# Altium schematic sheet SimpleFOCDriver.SchDoc
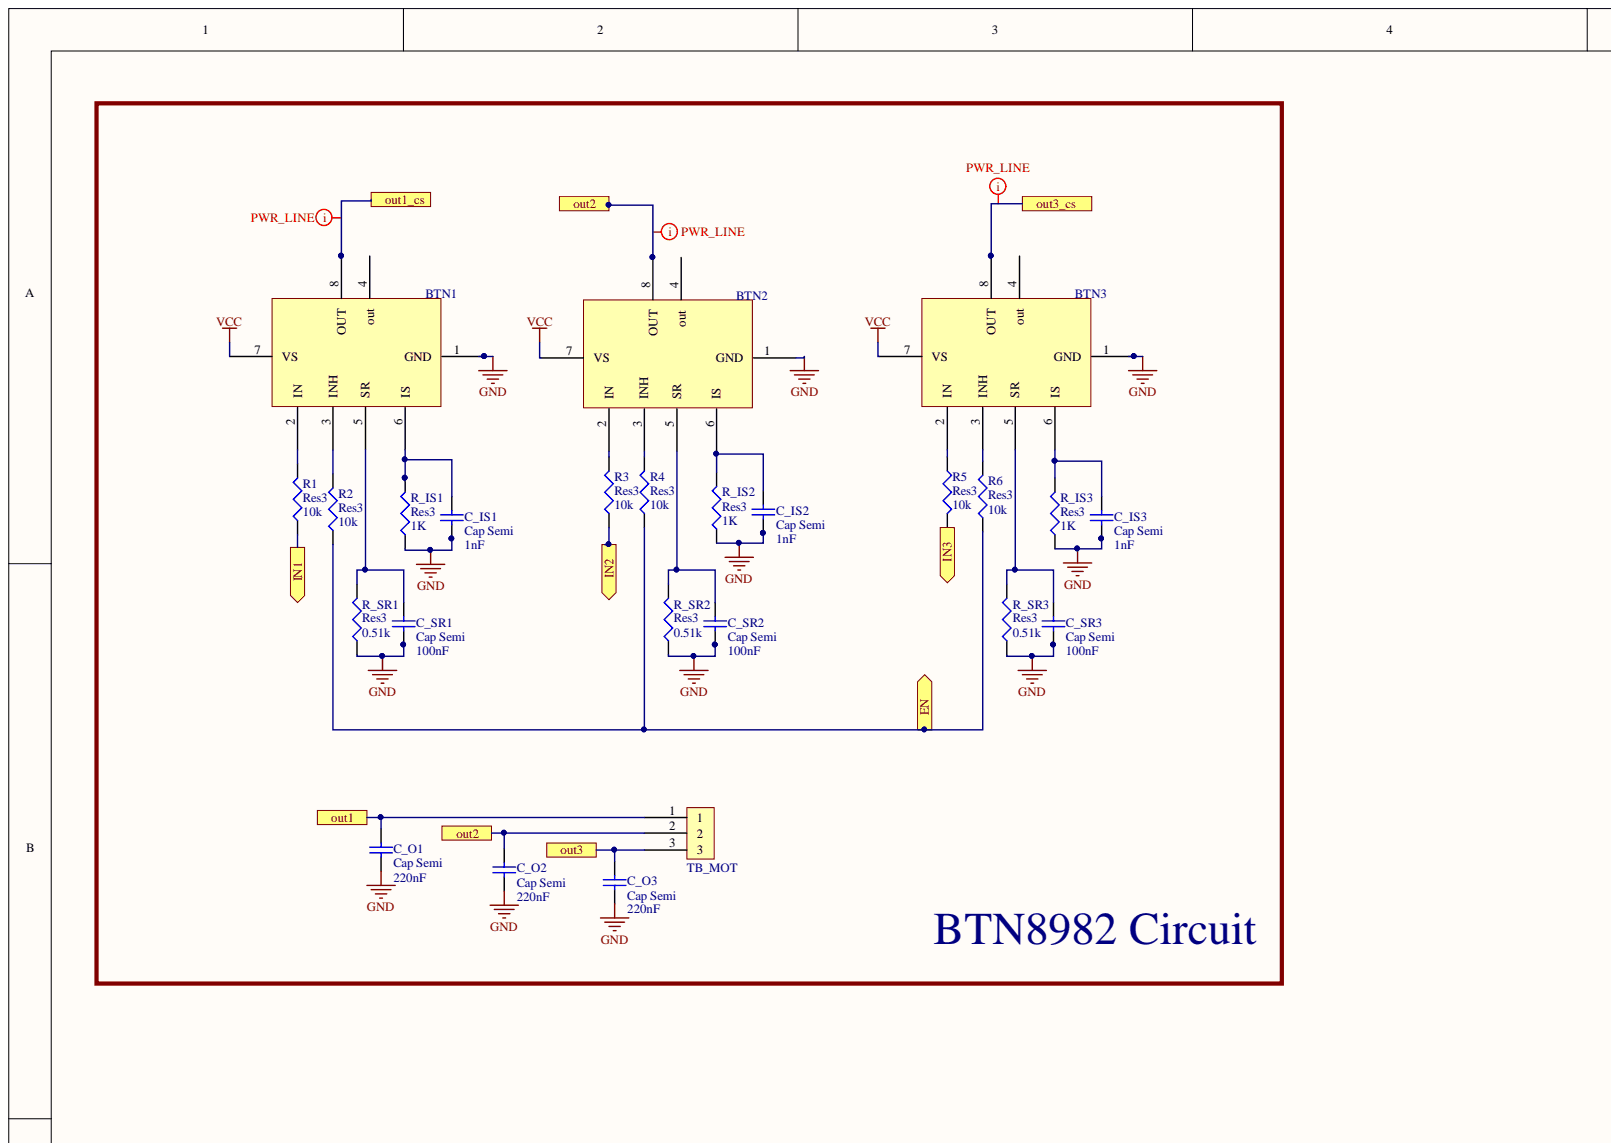
[diagram p0: SimpleFOCDriver.SchDoc, top left]
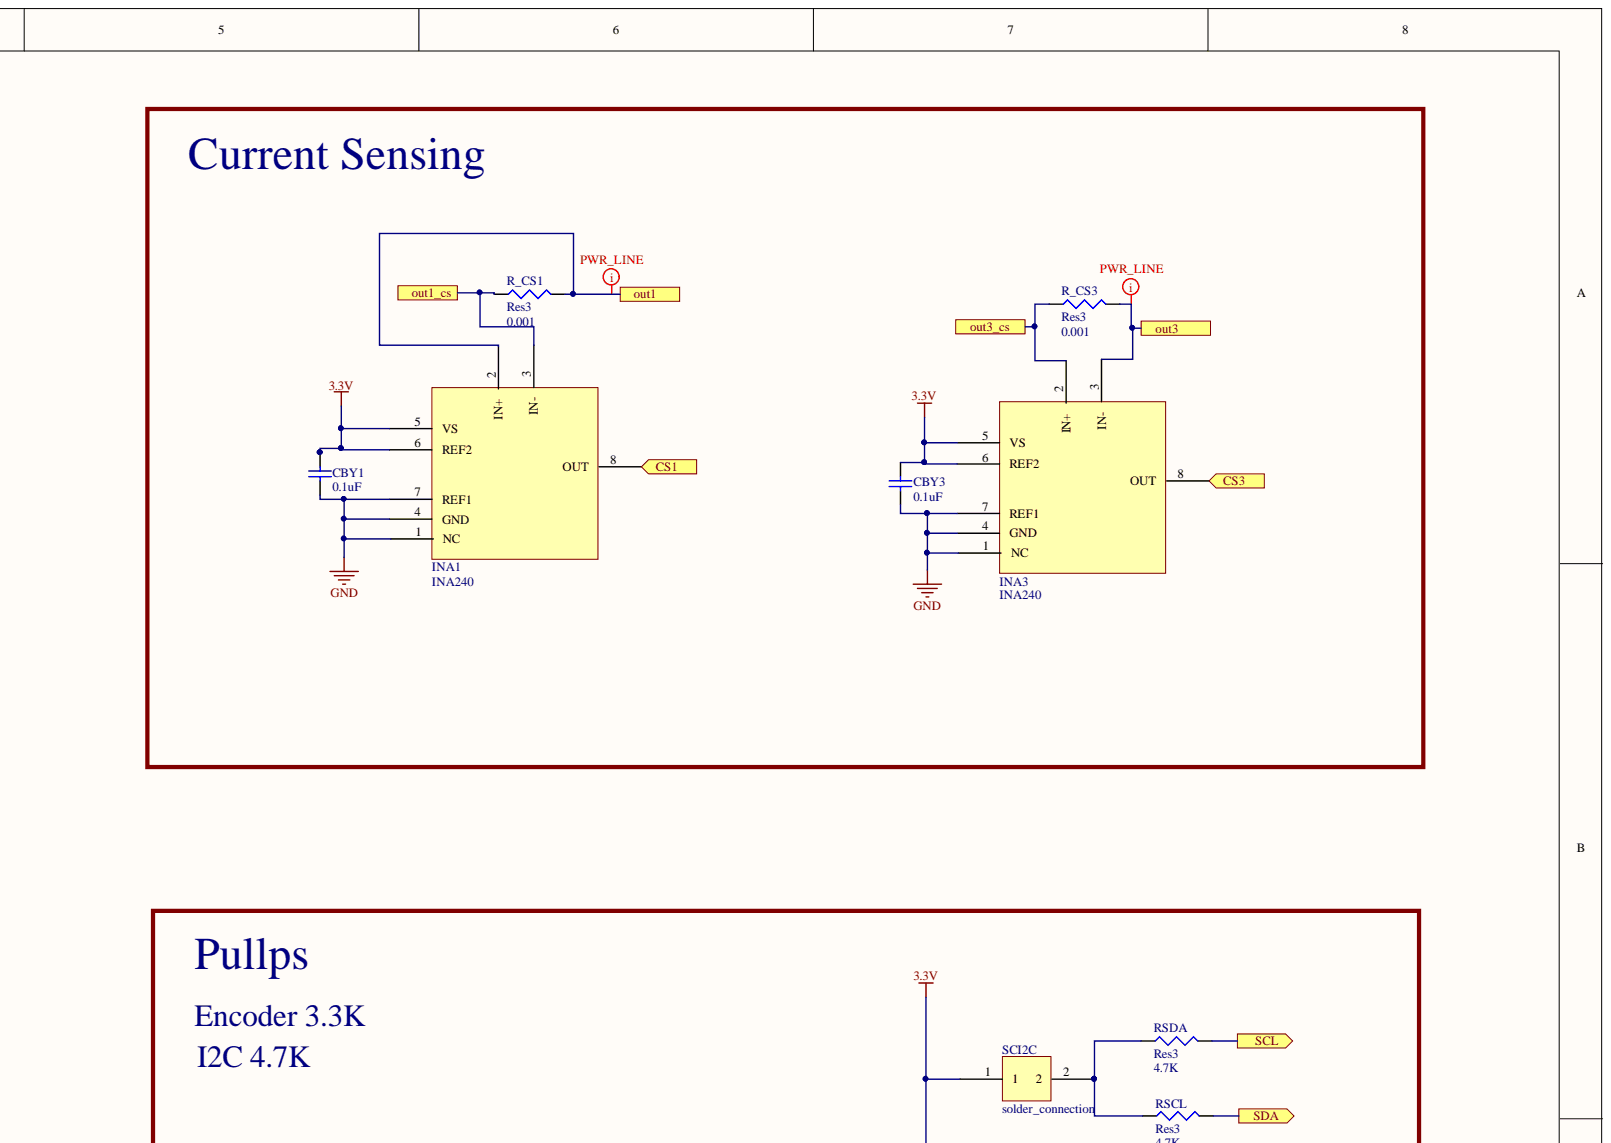
[diagram p1: SimpleFOCDriver.SchDoc, top right]
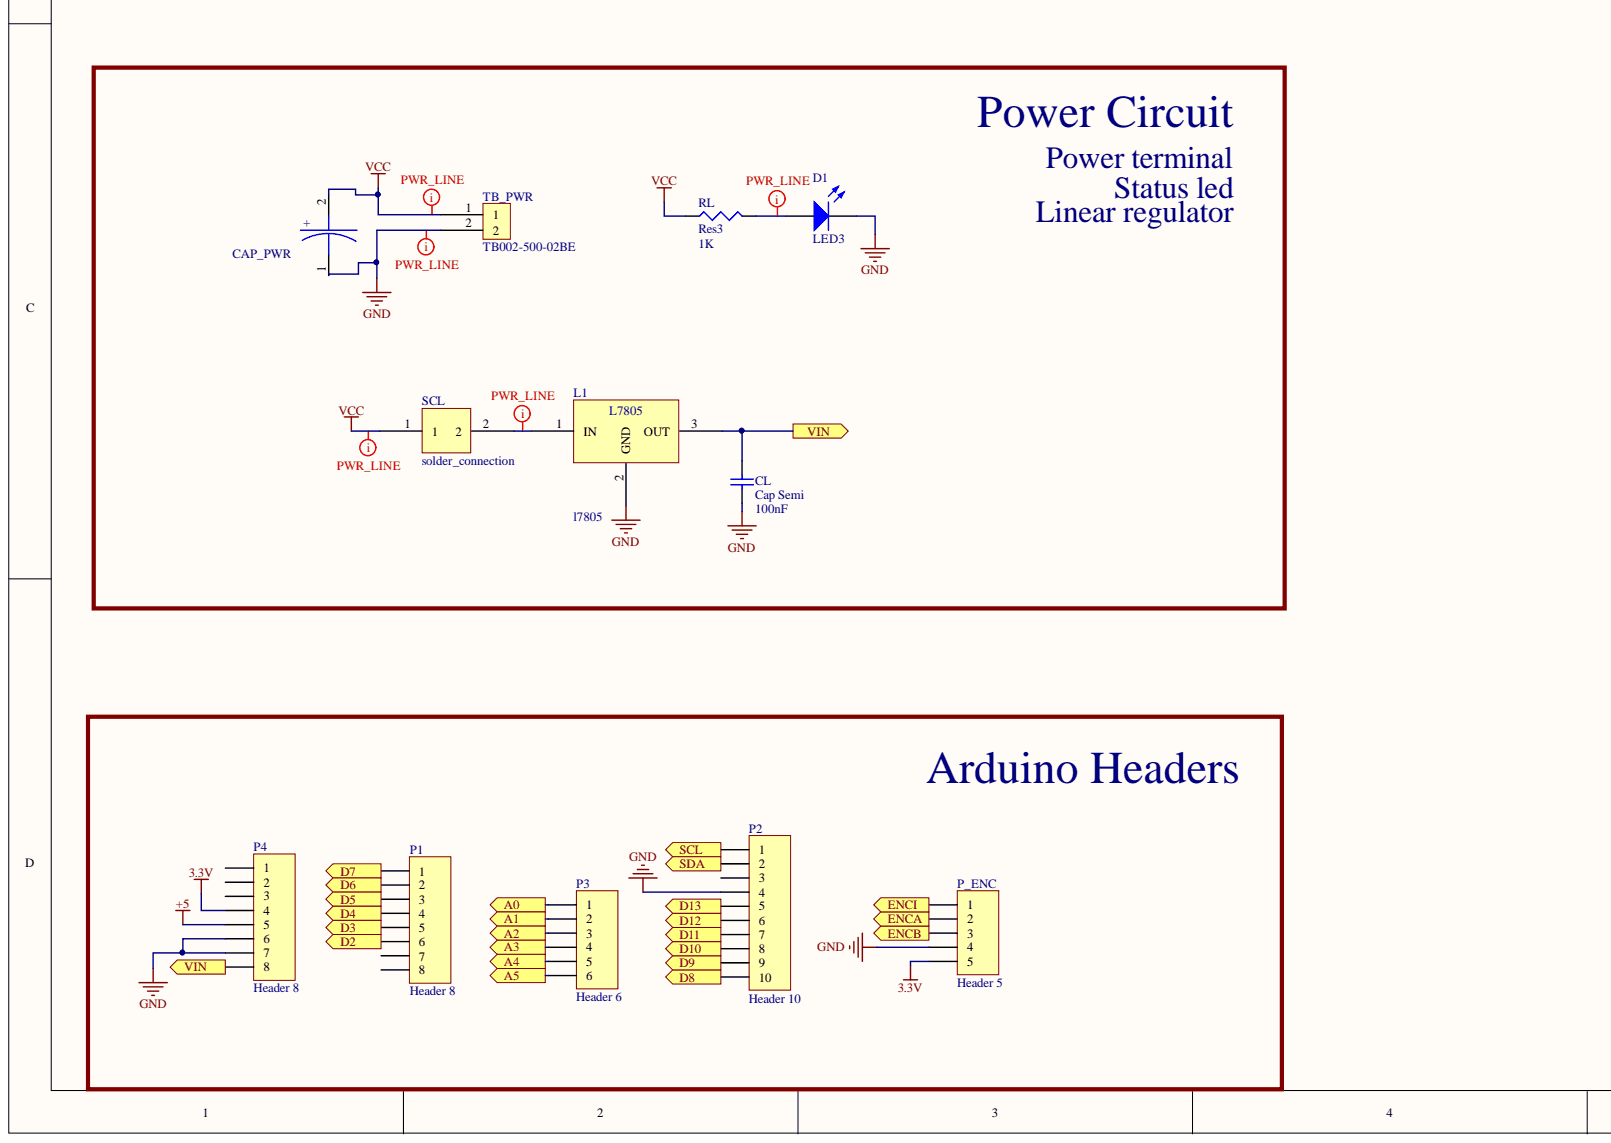
[diagram p2: SimpleFOCDriver.SchDoc, bottom left]
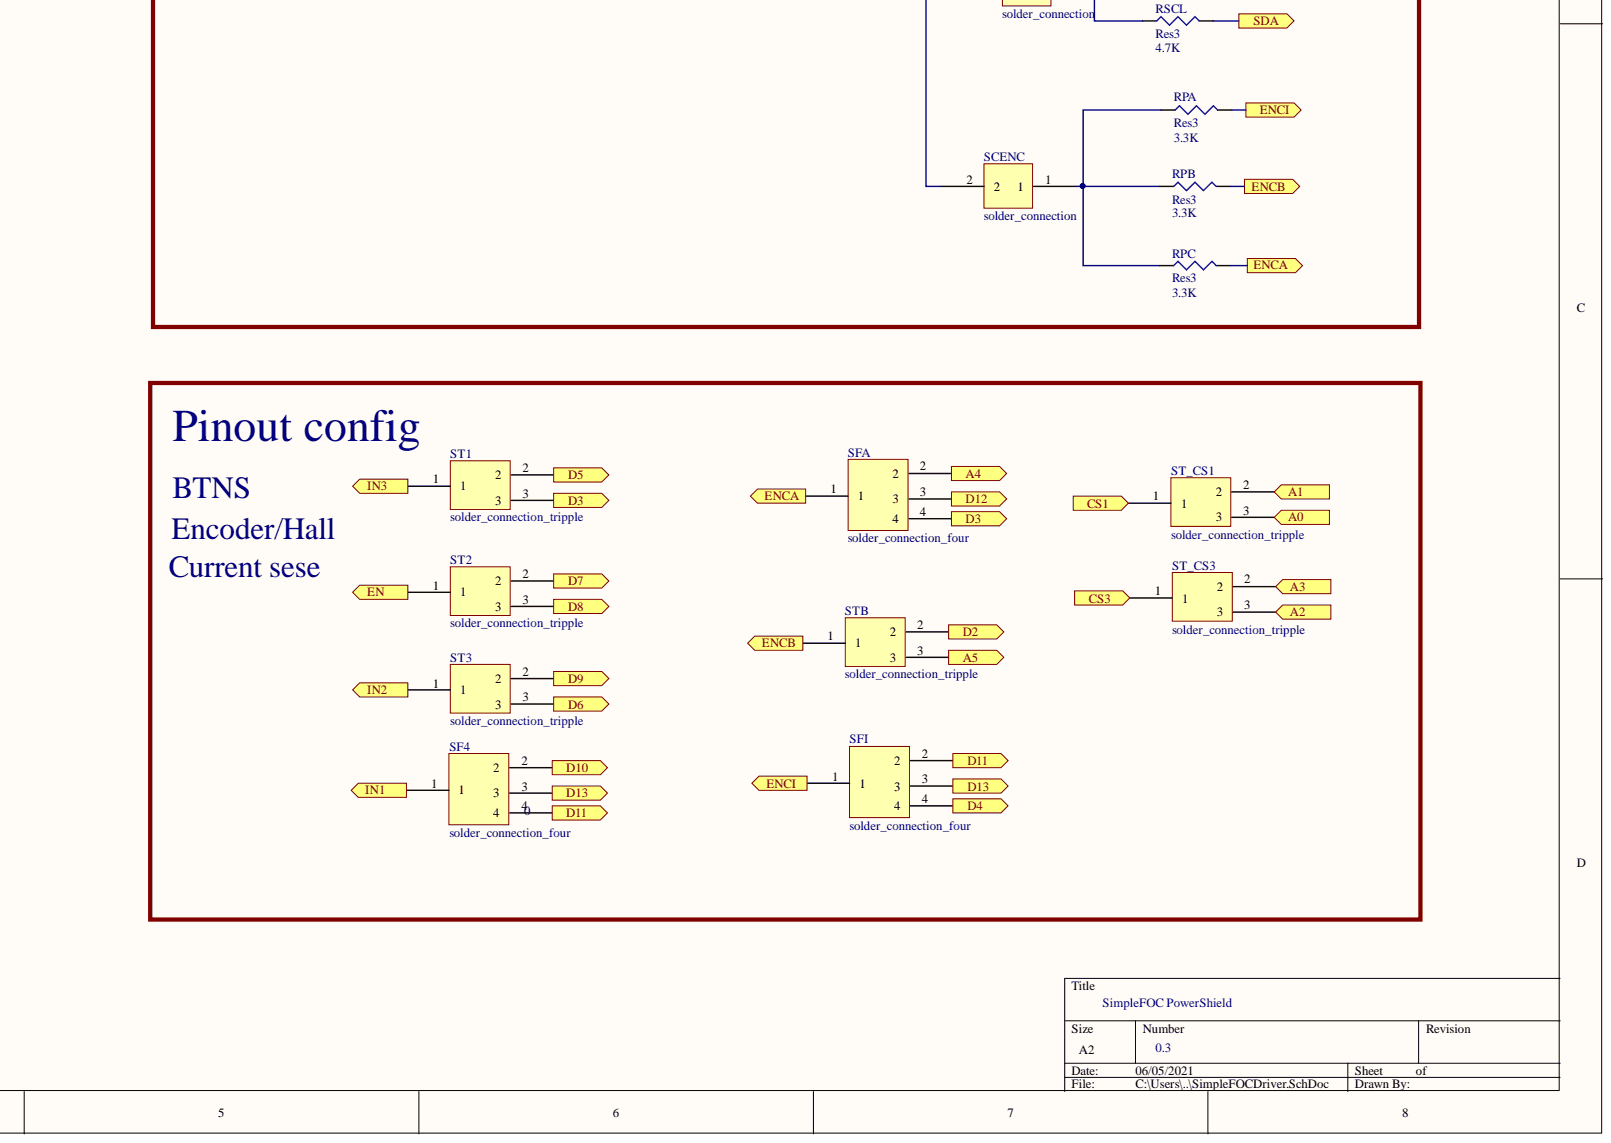
[diagram p3: SimpleFOCDriver.SchDoc, bottom right]

## Parts
BTN1  BTN8982  [BTN8982]  (value not drawn)
BTN2  BTN8982  [BTN8982]  (value not drawn)
BTN3  BTN8982  [BTN8982]  (value not drawn)
C_IS1  Cap Semi  value 1nF  [1608[0603]]
C_IS2  Cap Semi  value 1nF  [1608[0603]]
C_IS3  Cap Semi  value 1nF  [1608[0603]]
C_O1  Cap Semi  value 220nF  [1608[0603]]
C_O2  Cap Semi  value 220nF  [1608[0603]]
C_O3  Cap Semi  value 220nF  [1608[0603]]
C_SR1  Cap Semi  value 100nF  [1608[0603]]
C_SR2  Cap Semi  value 100nF  [1608[0603]]
C_SR3  Cap Semi  value 100nF  [1608[0603]]
CAP_PWR  RVT1V471M1010 VEJ471M1ETR1010  [VEJ471M1ETR1010]  (value not drawn)
CBY1  value 0.1uF  [1608[0603]]  (hidden comment: Cap Semi)
CBY3  value 0.1uF  [1608[0603]]  (hidden comment: Cap Semi)
CL  Cap Semi  value 100nF  [1608[0603]]
D1  LED3  [3.5X2.8X1.9]
INA1  INA240  [INA240]
INA3  INA240  [INA240]
L1  l7805  [L7805]
P1  Header 8  [HDR1X8]
P2  Header 10  [HDR1X10]
P3  Header 6  [HDR1X6]
P4  Header 8  [HDR1X8]
P_ENC  Header 5  [HDR1X5]
R1  Res3  value 10k  [J1-0603]
R2  Res3  value 10k  [J1-0603]
R3  Res3  value 10k  [J1-0603]
R4  Res3  value 10k  [J1-0603]
R5  Res3  value 10k  [J1-0603]
R6  Res3  value 10k  [J1-0603]
R_CS1  Res3  value 0.001  [RESC6332]
R_CS3  Res3  value 0.001  [RESC6332]
R_IS1  Res3  value 1K  [J1-0603]
R_IS2  Res3  value 1K  [J1-0603]
R_IS3  Res3  value 1K  [J1-0603]
R_SR1  Res3  value 0.51k  [J1-0603]
R_SR2  Res3  value 0.51k  [J1-0603]
R_SR3  Res3  value 0.51k  [J1-0603]
RL  Res3  value 1K  [J1-0603]
RPA  Res3  value 3.3K  [J1-0603]
RPB  Res3  value 3.3K  [J1-0603]
RPC  Res3  value 3.3K  [J1-0603]
RSCL  Res3  value 4.7K  [J1-0603]
RSDA  Res3  value 4.7K  [J1-0603]
SCENC  solder_connection  [solder_connection]
SCI2C  solder_connection  [solder_connection]
SCL  solder_connection  [solder_connection]
SF4  solder_connection_four  [solder_connection_four]
SFA  solder_connection_four  [solder_connection_four]
SFI  solder_connection_four  [solder_connection_four]
ST1  solder_connection_tripple  [solder_connection_tripple]
ST2  solder_connection_tripple  [solder_connection_tripple]
ST3  solder_connection_tripple  [solder_connection_tripple]
ST_CS1  solder_connection_tripple  [solder_connection_tripple]
ST_CS3  solder_connection_tripple  [solder_connection_tripple]
STB  solder_connection_tripple  [solder_connection_tripple]
TB_MOT  TB002-500-03BE  [TB002-500-03BE]  (value not drawn)
TB_PWR  TB002-500-02BE  [TB002-500-02BE]

## Ports
A0, A1, A2, A3, A4, A5, CS1, CS3, D2, D3, D4, D5, D6, D7, D8, D9, D10, D11, D12, D13, EN, ENCA, ENCB, ENCI, IN1, IN2, IN3, out1, out1_cs, out2, out3, out3_cs, SCL, SDA, VIN

## Nets
3.3V: CBY1.2 CBY3.2 INA1.5(VS) INA1.6(REF2) INA3.5(VS) INA3.6(REF2) P4.4 P_ENC.5 SCENC.2 SCI2C.1
+5: P4.5
GND: BTN1.1(GND) BTN2.1(GND) BTN3.1(GND) C_IS1.1 C_IS2.1 C_IS3.1 C_O1.2 C_O2.2 C_O3.2 C_SR1.1 C_SR2.1 C_SR3.1 CAP_PWR.1 CBY1.1 CBY3.1 CL.1 D1.2 INA1.1(NC) INA1.4(GND) INA1.7(REF1) INA3.1(NC) INA3.4(GND) INA3.7(REF1) L1.2 P2.4 P4.6 P4.7 P_ENC.4 R_IS1.1 R_IS2.1 R_IS3.1 R_SR1.1 R_SR2.1 R_SR3.1 TB_PWR.2
VCC: BTN1.7(VS) BTN2.7(VS) BTN3.7(VS) CAP_PWR.2 RL.1 SCL.1 TB_PWR.1
A0: P3.1 ST_CS1.3 ; port A0
A1: P3.2 ST_CS1.2 ; port A1
A2: P3.3 ST_CS3.3 ; port A2
A3: P3.4 ST_CS3.2 ; port A3
A4: P3.5 SFA.2 ; port A4
A5: P3.6 STB.3 ; port A5
CS1: INA1.8(OUT) ST_CS1.1 ; port CS1
CS3: INA3.8(OUT) ST_CS3.1 ; port CS3
D2: P1.6 STB.2 ; port D2
D3: P1.5 SFA.4 ST1.3 ; port D3
D4: P1.4 SFI.4 ; port D4
D5: P1.3 ST1.2 ; port D5
D6: P1.2 ST3.3 ; port D6
D7: P1.1 ST2.2 ; port D7
D8: P2.10 ST2.3 ; port D8
D9: P2.9 ST3.2 ; port D9
D10: P2.8 SF4.2 ; port D10
D11: P2.7 SF4.4 SFI.2 ; port D11
D12: P2.6 SFA.3 ; port D12
D13: P2.5 SF4.3 SFI.3 ; port D13
EN: R2.1 R4.1 R6.1 ST2.1 ; port EN
ENCA: P_ENC.2 RPC.2 SFA.1 ; port ENCA
ENCB: P_ENC.3 RPB.2 STB.1 ; port ENCB
ENCI: P_ENC.1 RPA.2 SFI.1 ; port ENCI
IN1: R1.1 SF4.1 ; port IN1
IN2: R3.1 ST3.1 ; port IN2
IN3: R5.2 ST1.1 ; port IN3
out1: C_O1.1 INA1.2(IN+) R_CS1.2 TB_MOT.1 ; port out1
out1_cs: BTN1.8(OUT) INA1.3(IN-) R_CS1.1 ; port out1_cs
out2: BTN2.8(OUT) C_O2.1 TB_MOT.2 ; port out2
out3: C_O3.1 INA3.3(IN-) R_CS3.2 TB_MOT.3 ; port out3
out3_cs: BTN3.8(OUT) INA3.2(IN+) R_CS3.1 ; port out3_cs
SCL: P2.1 RSDA.2 ; port SCL
SDA: P2.2 RSCL.2 ; port SDA
VIN: CL.2 L1.3 P4.8 ; port VIN
NetBTN1_2: BTN1.2(IN) R1.2
NetBTN1_3: BTN1.3(INH) R2.2
NetBTN1_5: BTN1.5(SR) C_SR1.2 R_SR1.2
NetBTN1_6: BTN1.6(IS) C_IS1.2 R_IS1.2
NetBTN2_2: BTN2.2(IN) R3.2
NetBTN2_3: BTN2.3(INH) R4.2
NetBTN2_5: BTN2.5(SR) C_SR2.2 R_SR2.2
NetBTN2_6: BTN2.6(IS) C_IS2.2 R_IS2.2
NetBTN3_2: BTN3.2(IN) R5.1
NetBTN3_3: BTN3.3 R6.2
NetBTN3_5: BTN3.5(SR) C_SR3.2 R_SR3.2
NetBTN3_6: BTN3.6(IS) C_IS3.2 R_IS3.2
NetD1_1: D1.1 RL.2
NetL1_1: L1.1 SCL.2
NetRPA_1: RPA.1 RPB.1 RPC.1 SCENC.1
NetRSCL_1: RSCL.1 RSDA.1 SCI2C.2

Not connected: BTN1 4; BTN2 4(out); BTN3 4(out); P1 7 8; P2 3; P4 1 2 3

## Library data of the parts
C_IS1-C_IS3 C_O1-C_O3 C_SR1-C_SR3 CBY1 CBY3 CL = Cap Semi: Capacitor (Semiconductor SIM Model); Component Kind: Standard; LatestRevisionDate: 29-May-2009; LatestRevisionNote: IPC-7351 Footprint Added.; Library Name: Miscellaneous Devices.IntLib; PackageDescription: Chip Capacitor; Published: 8-Jun-2000; Signal Integrity: Cap Semi; Simulation: CAP; Publisher: Altium Limited
  C_IS1-C_IS3 CBY1 CBY3: PackageReference: C0603
  C_O1-C_O3 C_SR1-C_SR3 CL: PackageReference: C1206
CAP_PWR = VEJ471M1ETR1010: Component Kind: Standard; Library Name: ArduinoFOC.SchLib; Supplier 1: Mouser; Supplier Part Number 1: 140-VEJ471M1ETR1010
D1 = LED3: Typical BLUE SiC LED; Component Kind: Standard; DatasheetVersion: 16-Apr-2002; LatestRevisionDate: 17-Dec-2003; LatestRevisionNote: PCB Footprint replaced.; Library Name: Miscellaneous Devices.IntLib; PackageDescription: SM LED; 2 C-Bend Leads; Body 3.5 x 2.8 x 1.9 mm, inc leads (LxWxH typ); PackageReference: 3.5X2.8X1.9; PackageVersion: 16-Apr-2002; Published: 8-Jun-2000; Signal Integrity: LED; Simulation: LED3; Publisher: Altium Limited
P1 P4 = Header 8: Header, 8-Pin; Component Kind: Standard; LatestRevisionDate: 17-Jul-2002; LatestRevisionNote: Re-released for DXP Platform.; Library Name: Miscellaneous Connectors.IntLib; Signal Integrity: Connector; Publisher: Altium Limited
P2 = Header 10: Header, 10-Pin; Component Kind: Standard; LatestRevisionDate: 17-Jul-2002; LatestRevisionNote: Re-released for DXP Platform.; Library Name: Miscellaneous Connectors.IntLib; Signal Integrity: Connector; Publisher: Altium Limited
P3 = Header 6: Header, 6-Pin; Component Kind: Standard; LatestRevisionDate: 17-Jul-2002; LatestRevisionNote: Re-released for DXP Platform.; Library Name: Miscellaneous Connectors.IntLib; Signal Integrity: Connector; Publisher: Altium Limited
P_ENC = Header 5: Header, 5-Pin; Component Kind: Standard; LatestRevisionDate: 17-Jul-2002; LatestRevisionNote: Re-released for DXP Platform.; Library Name: Miscellaneous Connectors.IntLib; Signal Integrity: Connector; Publisher: Altium Limited
R1-R6 R_CS1 R_CS3 R_IS1-R_IS3 R_SR1-R_SR3 RL RPA RPB RPC RSCL RSDA = Res3: Resistor; Component Kind: Standard; LatestRevisionDate: 29-May-2009; LatestRevisionNote: IPC-7351 Footprint Added.; Library Name: Miscellaneous Devices.IntLib; PackageDescription: Chip Resistor; Published: 8-Jun-2000; Signal Integrity: Res; Simulation: RESISTOR; Publisher: Altium Limited
  R1-R6 R_IS1-R_IS3 R_SR1-R_SR3 RL RPA RPB RPC RSCL RSDA: PackageReference: J1-0603
  R_CS1 R_CS3: PackageReference: RESC6332
BTN1-BTN3 = BTN8982: Component Kind: Standard; Description: BTN8982; Library Name: ArduinoFOC.SchLib; Supplier 1: RSComponents
INA1 INA3 = INA240: Component Kind: Standard; Library Name: ArduinoFOC.SchLib; Supplier 1: Mouser; Supplier Part Number 1: 595-INA240A2PWR
L1 = l7805: Component Kind: Standard; Library Name: ArduinoFOC.SchLib
SCENC SCI2C SCL = solder_connection: Component Kind: Standard; Library Name: ArduinoFOC.SchLib
SF4 SFA SFI = solder_connection_four: Component Kind: Standard; Library Name: ArduinoFOC.SchLib
ST1-ST3 ST_CS1 ST_CS3 STB = solder_connection_tripple: Component Kind: Standard; Library Name: ArduinoFOC.SchLib
TB_MOT = TB002-500-03BE: Component Kind: Standard; Library Name: ArduinoFOC.SchLib; Supplier 1: Mouser; Supplier Part Number 1: 490-TB002-500-03BE
TB_PWR = TB002-500-02BE: Component Kind: Standard; Library Name: ArduinoFOC.SchLib; Supplier 1: Mouser; Supplier Part Number 1: 490-TB002-500-02BE
